# Altium schematic sheet 3.ETH.SchDoc(3.ETH)
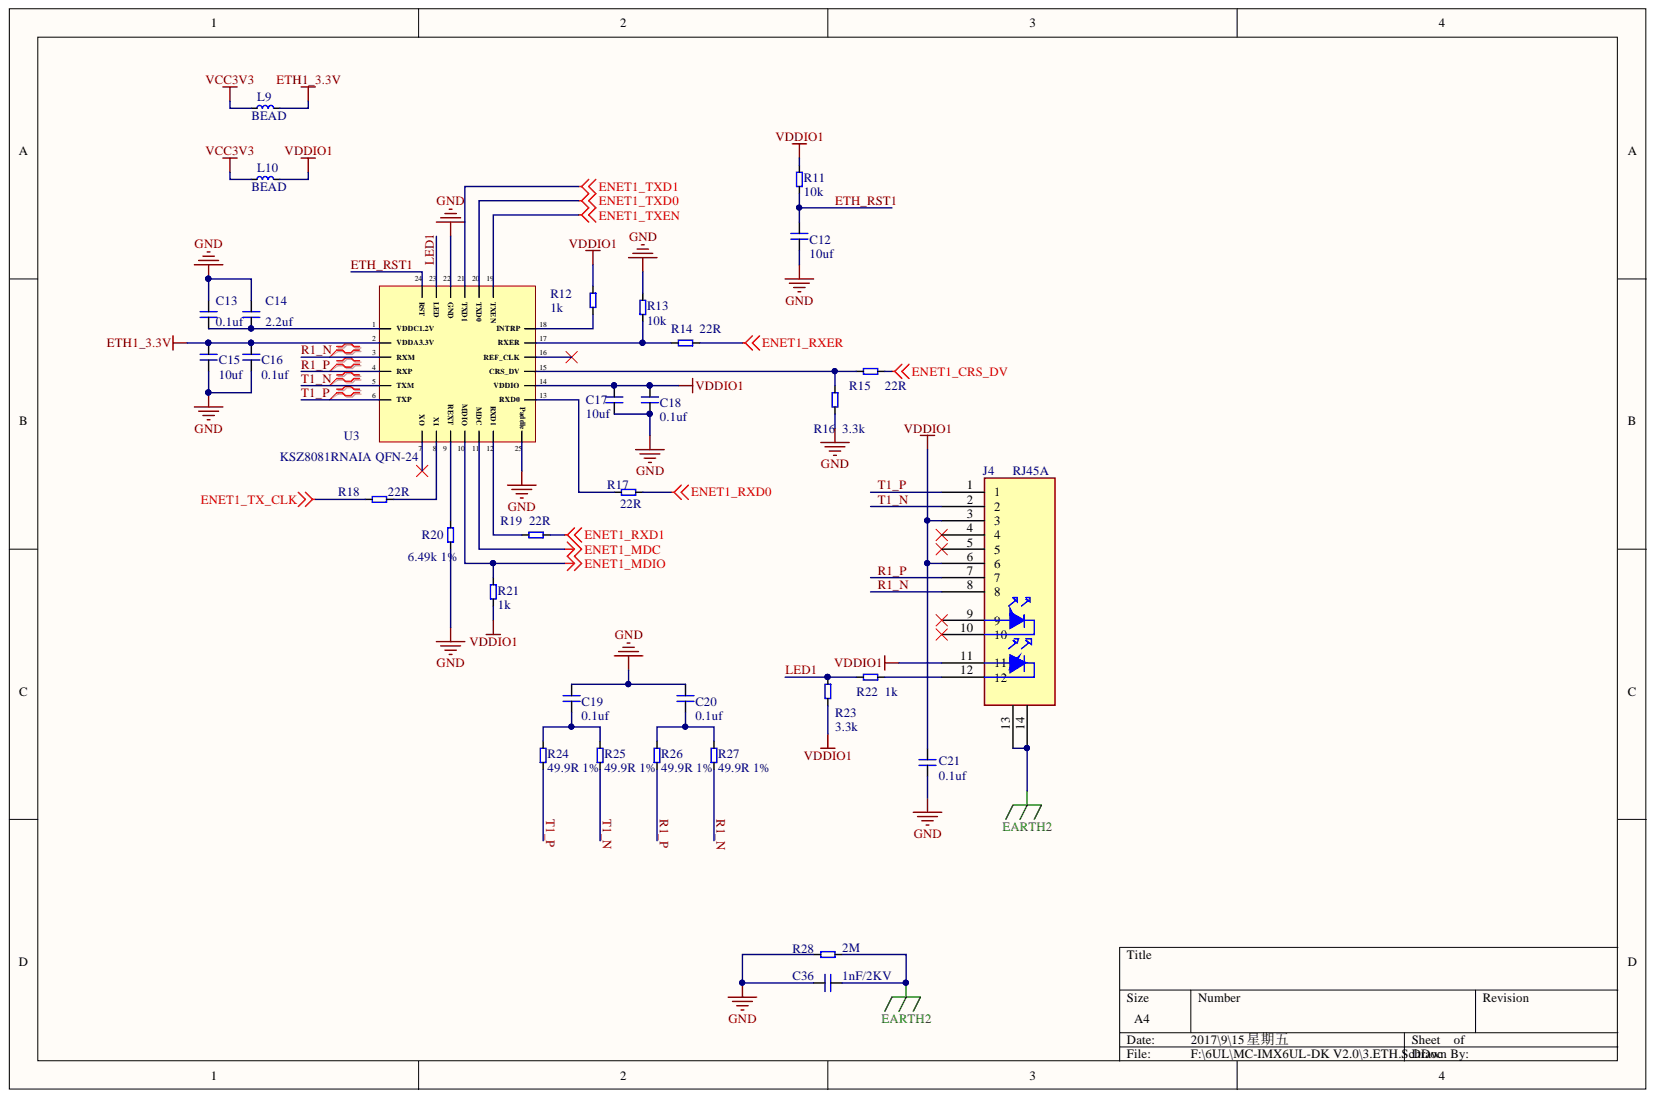
[diagram p0: 3.ETH.SchDoc]

## Parts
C12  10uf  [0603C]
C13  0.1uf  [0603C]
C14  2.2uf  [0603C]
C15  10uf  [0603C]
C16  0.1uf  [0603C]
C17  10uf  [0603C]
C18  0.1uf  [0603C]
C19  0.1uf  [0603C]
C20  0.1uf  [0603C]
C21  0.1uf  [0603C]
C36  1nF/2KV  [DC-200]
J4  RJ45A  [RJ45B-13F-60LDNL]
L9  BEAD  [0805L]
L10  BEAD  [0805L]
R11  10k  [0603R]
R12  1k  [0603R]
R13  10k  [0603R]
R14  22R  [0603R]
R15  22R  [0603R]
R16  3.3k  [0603R]
R17  22R  [0603R]
R18  22R  [0603R]
R19  22R  [0603R]
R20  6.49k 1%  [0603R]
R21  1k  [0603R]
R22  1k  [0603R]
R23  3.3k  [0603R]
R24  49.9R 1%  [0603R]  (value not drawn)
R25  49.9R 1%  [0603R]
R26  49.9R 1%  [0603R]
R27  49.9R 1%  [0603R]
R28  2M  [0805R]
U3  KSZ8081RNAIA QFN-24  [QFN-24]

## Nets
EARTH2: C36.2 J4.13 J4.14 R28.1
ENET1_CRS_DV: R15.1
ENET1_MDC: U3.11(MDC)
ENET1_MDIO: R21.2 U3.10(MDIO)
ENET1_RXD0: R17.1
ENET1_RXD1: R19.1
ENET1_RXER: R14.1
ENET1_TX_CLK: R18.2
ENET1_TXD0: U3.20(TXD0)
ENET1_TXD1: U3.21(TXD1)
ENET1_TXEN: U3.19(TXEN)
ETH1_3.3V: C15.1 C16.1 L9.2 U3.2(VDDA3.3V)
GND: C12.1 C13.1 C14.1 C15.2 C16.2 C17.2 C18.2 C19.1 C20.1 C21.1 C36.1 R13.2 R16.2 R20.1 R28.2 U3.22(GND) U3.25(Paddle)
VCC3V3: L9.1 L10.1
VDDIO1: C17.1 C18.1 C21.2 J4.3 J4.6 J4.11 L10.2 R11.2 R12.1 R21.1 R23.2 U3.14(VDDIO)
ETH_RST1: C12.2 R11.1 U3.24(RST)
LED1: R22.2 R23.1 U3.23(LED)
R1_N: J4.8 R27.1 U3.3(RXM)
R1_P: J4.7 R26.1 U3.4(RXP)
T1_N: J4.2 R25.1 U3.5(TXM)
T1_P: J4.1 R24.1 U3.6(TXP)
NetC13_2: C13.2 C14.2 U3.1(VDDC1.2V)
NetC19_2: C19.2 R24.2 R25.2
NetC20_2: C20.2 R26.2 R27.2
NetJ4_12: J4.12 R22.1
NetR12_2: R12.2 U3.18(INTRP)
NetR13_1: R13.1 R14.2 U3.17(RXER)
NetR15_2: R15.2 R16.1 U3.15(CRS_DV)
NetR17_2: R17.2 U3.13(RXD0)
NetR18_1: R18.1 U3.8(XI)
NetR19_2: R19.2 U3.12(RXD1)
NetR20_2: R20.2 U3.9(REXT)

Not connected: J4 4 5 9 10; U3 7(XO) 16(REF_CLK)

## Library data of the parts
C12-C21 C36 = 电容: Component Kind: Standard; Description: 电容
  C12-C21: Library Name: LDT-SCH.SCHLIB
L9 L10 = 电感: Component Kind: Standard; Description: 电感; Library Name: LDT1-SCH.SCHLIB
R11-R28 = 电阻: Component Kind: Standard; Description: 电阻
  R11 R20: Library Name: LDT1-SCH.SCHLIB
  R12-R19 R21-R28: Library Name: LDT-SCH.SCHLIB
U3 = KSZ8081RNA QFN-24: Component Kind: Standard; Library Name: MY.SchLib
J4 = RJ45A: Component Kind: Standard; Library Name: LDT-SCH.SCHLIB
